# Altium schematic sheet SC1.0.SchDoc(SC1.0)
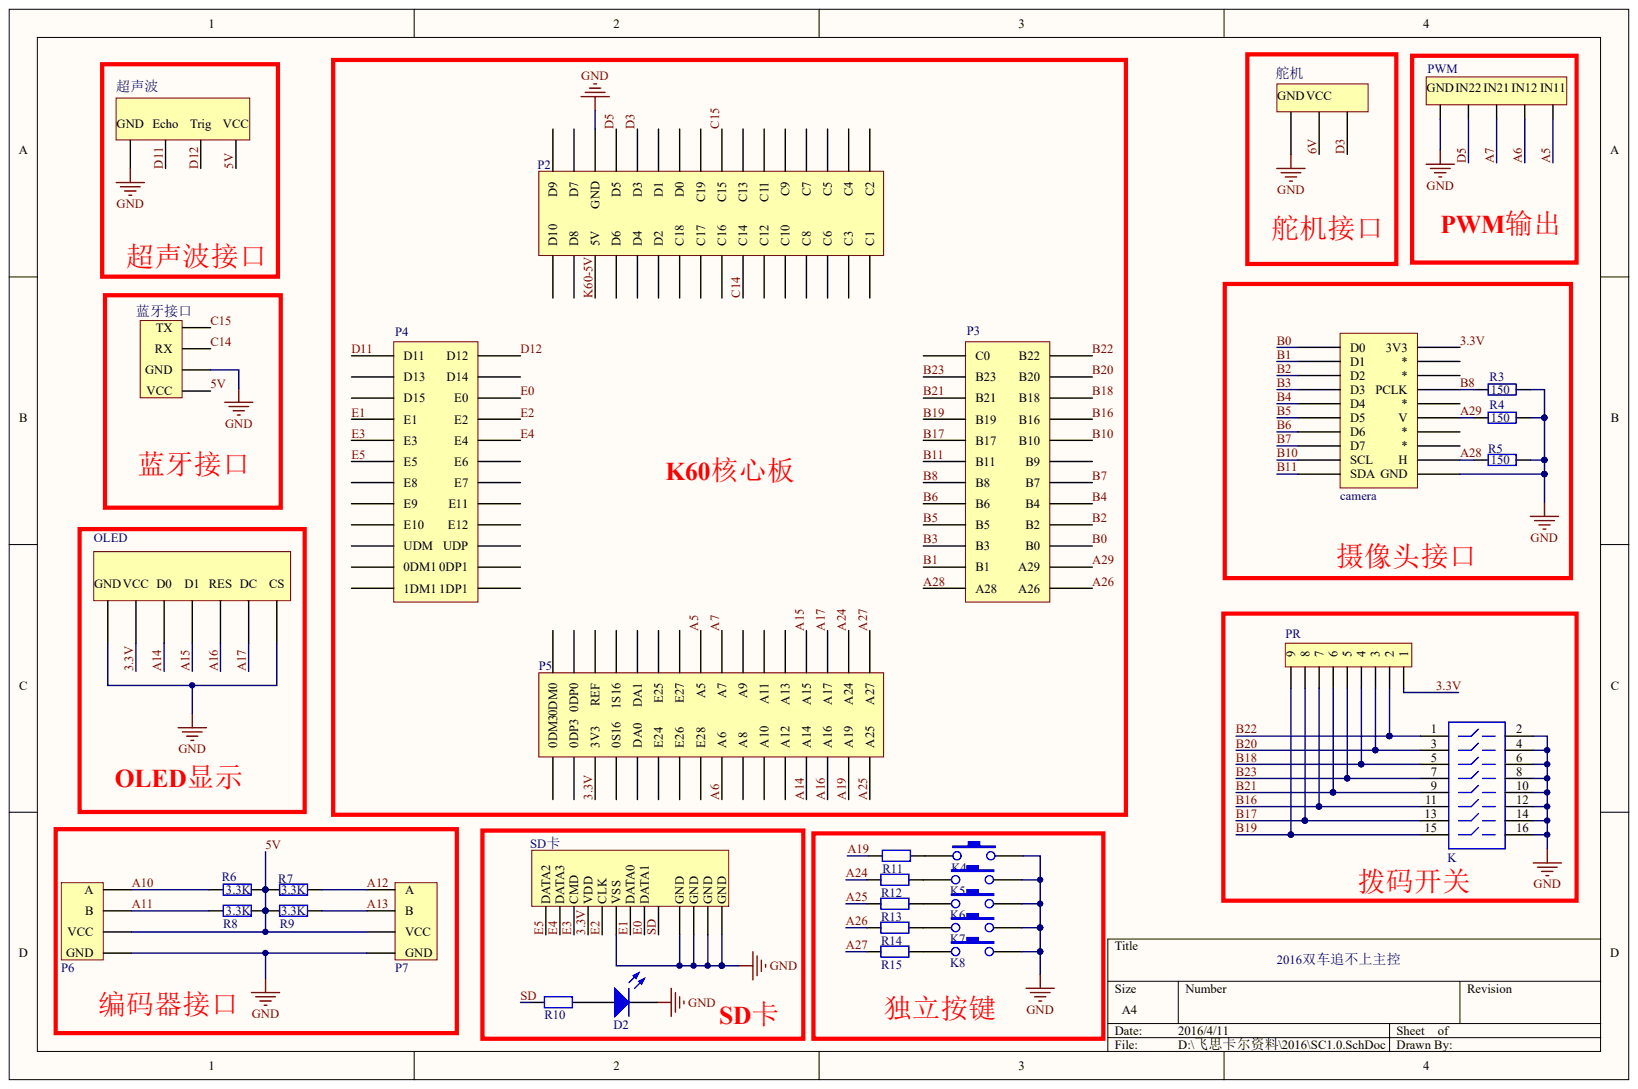
[diagram p0: SC1.0.SchDoc]

## Parts
camera  camera
D2  LED  (value not drawn)
K  拨码开关
K4  贴片开关  (value not drawn)
K5  贴片开关  (value not drawn)
K6  贴片开关  (value not drawn)
K7  贴片开关  (value not drawn)
K8  贴片开关  (value not drawn)
OLED  OLED
P2  MK60  (value not drawn)
P3  MK60ÓÒ  (value not drawn)
P4  MK60×ó  (value not drawn)
P5  MK60ÏÂ  (value not drawn)
P6  编码器
P7  编码器  (value not drawn)
PR  排阻  (value not drawn)
PWM  PWM
R3  150
R4  150
R5  150
R6  3.3K
R7  3.3K
R8  3.3K
R9  3.3K
R10  电阻  (value not drawn)
R11  电阻  (value not drawn)
R12  电阻  (value not drawn)
R13  电阻  (value not drawn)
R14  电阻  (value not drawn)
R15  电阻  (value not drawn)
SD卡  SD卡座  (value not drawn)
舵机  舵机
蓝牙接口  蓝牙接口
超声波  超声波

## Nets
GND: camera.20(GND) D2.2 K.2 K.4 K.6 K.8 K.10 K.12 K.14 K.16 K4.2 K5.2 K6.2 K7.2 K8.2 OLED.1 OLED.7(CS) P2.27(GND) P6.4(GND) P7.4(GND) PWM.1 R3.2 R4.2 R5.2 SD卡.6(VSS) SD卡.10(GND) SD卡.11(GND) SD卡.12(GND) SD卡.13(GND) 舵机.3 蓝牙接口.3(GND) 超声波.1
3.3V: camera.2(3V3) OLED.2 P5.28(3V3) PR.1 SD卡.4(VDD)
5V: P6.3(VCC) P7.3(VCC) R6.2 R7.1 R8.2 R9.1 蓝牙接口.4(VCC) 超声波.4
6V: 舵机.2
A5: P5.17(A5) PWM.5
A6: P5.16(A6) PWM.4
A7: P5.15(A7) PWM.3
A10: P6.1(A) R6.1
A11: P6.2(B) R8.1
A12: P7.1(A) R7.2
A13: P7.2(B) R9.2
A14: OLED.3(D0) P5.8(A14)
A15: OLED.4(D1) P5.7(A15)
A16: OLED.5 P5.6(A16)
A17: OLED.6(DC) P5.5(A17)
A19: P5.4(A19) R11.1
A24: P5.3(A24) R12.1
A25: P5.2(A25) R13.1
A26: P3.24(A26) R14.1
A27: P5.1(A27) R15.1
A28: camera.18(H) P3.23(A28) R5.1
A29: camera.12(V) P3.22(A29) R4.1
B0: camera.1(D0) P3.20(B0)
B1: camera.3(D1) P3.21(B1)
B2: camera.5(D2) P3.18(B2)
B3: camera.7(D3) P3.19(B3)
B4: camera.9(D4) P3.16(B4)
B5: camera.11(D5) P3.17(B5)
B6: camera.13(D6) P3.15(B6)
B7: camera.15(D7) P3.14(B7)
B8: camera.8(PCLK) P3.13(B8) R3.1
B10: camera.17(SCL) P3.10(B10)
B11: camera.19(SDA) P3.11(B11)
B16: K.11 P3.8(B16) PR.7
B17: K.13 P3.9(B17) PR.8
B18: K.5 P3.6(B18) PR.4
B19: K.15 P3.7(B19) PR.9
B20: K.3 P3.4(B20) PR.3
B21: K.9 P3.5(B21) PR.6
B22: K.1 P3.2(B22) PR.2
B23: K.7 P3.3(B23) PR.5
C14: P2.14(C14) 蓝牙接口.2(RX)
C15: P2.15(C15) 蓝牙接口.1(TX)
D3: P2.23(D3) 舵机.1
D5: P2.25(D5) PWM.2
D11: P4.1(D11) 超声波.2
D12: P4.2(D12) 超声波.3
E0: P4.6(E0) SD卡.8(DATA1)
E1: P4.7(E1) SD卡.7(DATA0)
E2: P4.8(E2) SD卡.5(CLK)
E3: P4.9(E3) SD卡.3(CMD)
E4: P4.10(E4) SD卡.2(DATA3)
E5: P4.11(E5) SD卡.1(DATA2)
K60-5V: P2.28(5V)
SD: R10.1 SD卡.9
NetD2_1: D2.1 R10.2
NetK4_1: K4.1 R11.2
NetK5_1: K5.1 R12.2
NetK6_1: K6.1 R13.2
NetK7_1: K7.1 R14.2
NetK8_1: K8.1 R15.2

Not connected: camera 4(*) 6(*) 10(*) 14(*) 16(*); P2 1(C2) 2(C1) 3(C4) 4(C3) 5(C5) 6(C6) 7(C7) 8(C8) 9(C9) 10(C10) 11(C11) 12(C12) 13(C13) 16(C16) 17(C19) 18(C17) 19(D0) 20(C18) 21(D1) 22(D2) 24(D4) 26(D6) 29(D7) 30(D8) 31(D9) 32(D10); P3 1(C0) 12(B9); P4 3(D13) 4(D14) 5(D15) 12(E6) 13(E8) 14(E7) 15(E9) 16(E11) 17(E10) 18(E12) 19(UDM) 20(UDP) 21(0DM1) 22(0DP1) 23(1DM1) 24(1DP1); P5 9(A13) 10(A12) 11(A11) 12(A10) 13(A9) 14(A8) 18(E28) 19(E27) 20(E26) 21(E25) 22(E24) 23(DA1) 24(DA0) 25(1S16) 26(0S16) 27(REF) 29(0DP0) 30(0DP3) 31(0DM0) 32(0DM3)
